# Altium schematic sheet Voltage Signal Measuring.SchDoc("Voltage Signal Measuring")
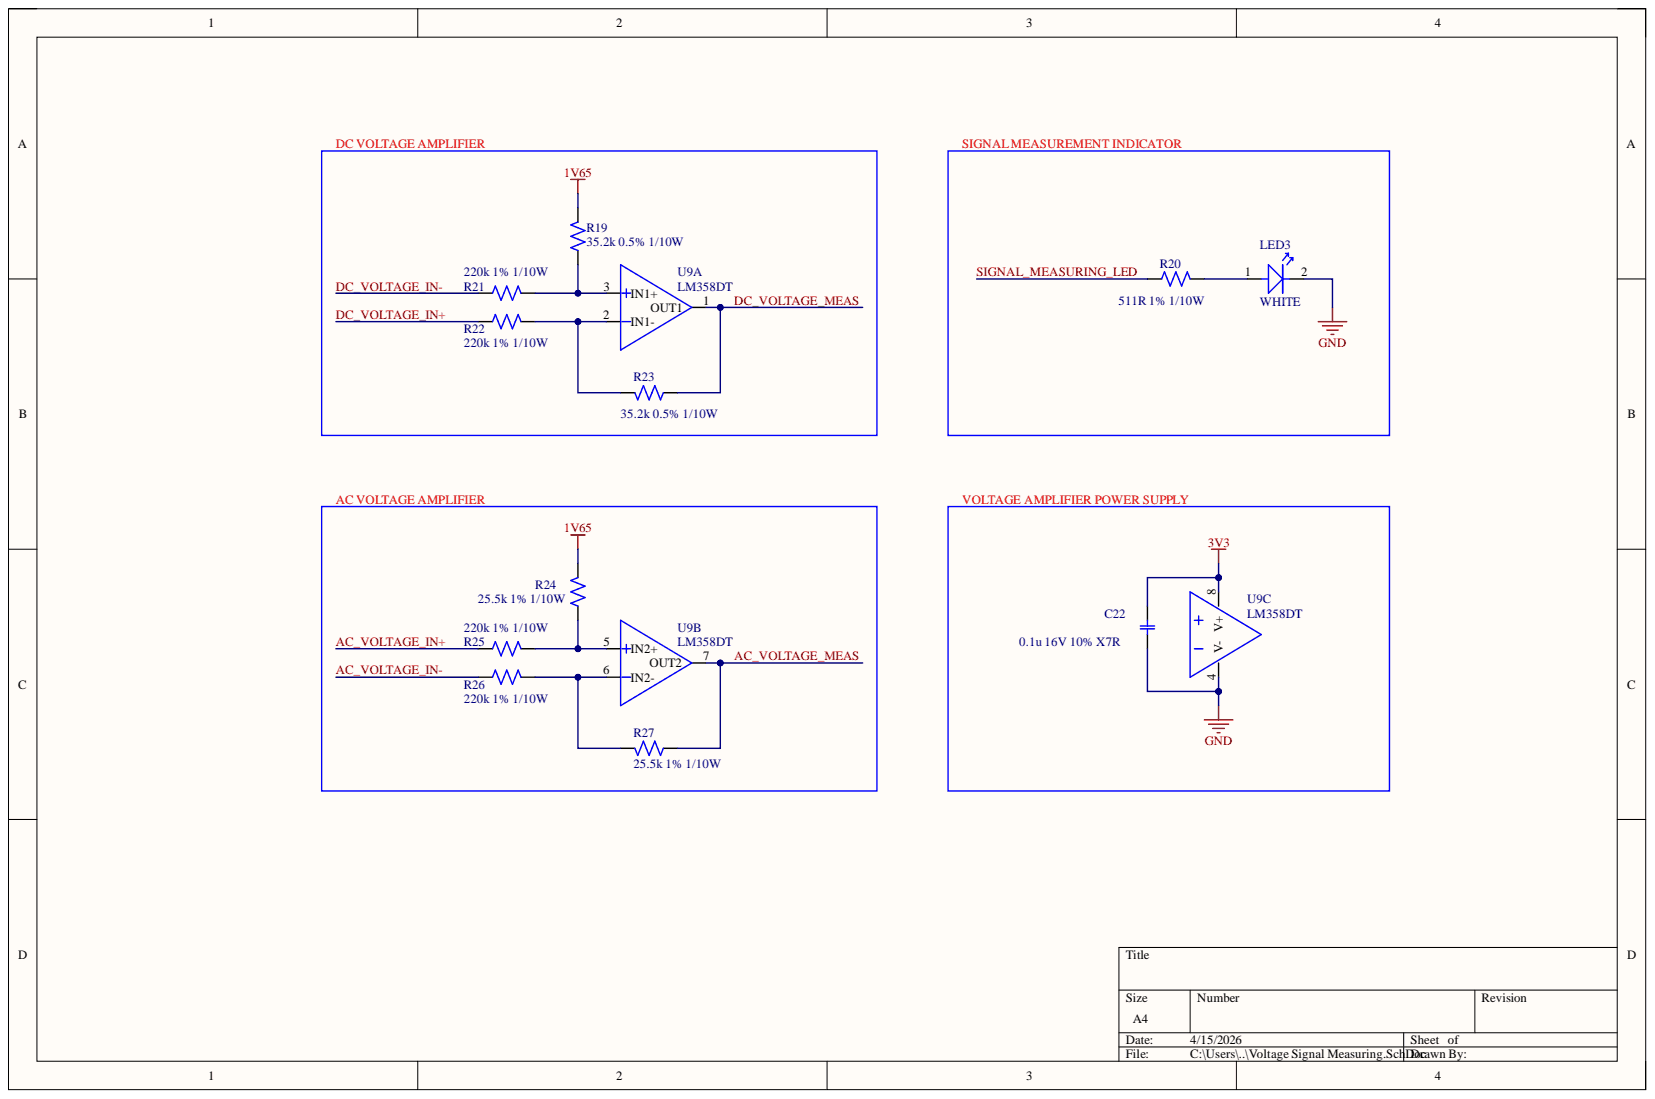
[diagram p0: Voltage Signal Measuring.SchDoc]

## Parts
C22  0.1u 16V 10% X7R  Dielectric: X7R  [0603-C]
LED3  WHITE  [0603 - LED]
R19  35.2k 0.5% 1/10W  [0603-R]
R20  511R 1% 1/10W  Tolerance: 1%  [0603-R]
R21  220k 1% 1/10W  Tolerance: 1%  [0603-R]
R22  220k 1% 1/10W  Tolerance: 1%  [0603-R]
R23  35.2k 0.5% 1/10W  Tolerance: 0.5%  [0603-R]
R24  25.5k 1% 1/10W  [0603-R]
R25  220k 1% 1/10W  Tolerance: 1%  [0603-R]
R26  220k 1% 1/10W  Tolerance: 1%  [0603-R]
R27  25.5k 1% 1/10W  Tolerance: 1%  [0603-R]
U9  LM358DT  [LM358DT]  (parts A,B,C)

## Nets
1V65: R19.1 R24.1
3V3: C22.1 U9.8(V+)
GND: C22.2 LED3.2 U9.4(V-)
AC_VOLTAGE_IN+: R25.2
AC_VOLTAGE_IN-: R26.2
AC_VOLTAGE_MEAS: R27.2 U9.7(OUT2)
DC_VOLTAGE_IN+: R22.2
DC_VOLTAGE_IN-: R21.2
DC_VOLTAGE_MEAS: R23.2 U9.1(OUT1)
SIGNAL_MEASURING_LED: R20.2
NetLED3_1: LED3.1 R20.1
NetR19_2: R19.2 R21.1 U9.3(IN1+)
NetR22_1: R22.1 R23.1 U9.2(IN1-)
NetR24_2: R24.2 R25.1 U9.5(IN2+)
NetR26_1: R26.1 R27.1 U9.6(IN2-)

## Library data of the parts
C22 = CC0603KRX7R7BB104: 0603 0.1 uF 16 V ±10 % Tolerance X7R SMT Multilayer Ceramic Capacitor; Manufacturer: Yageo; Insulation Resistance: 100GR; Value: 100nF; Case Code (Imperial): 0603; Case Code (Metric): 1608; Case/Package: 0603; Category: Ceramic Capacitors; Component Kind: Standard; ComponentLink1Description: https://datasheet.ciiva.com/11786/0900766b80d84f1d-11786077.pdf?src-supplier=Rs; ComponentLink1URL: https://datasheet.ciiva.com/11786/0900766b80d84f1d-11786077.pdf?src-supplier=Rs; ComponentLink2Description: https://datasheet.ciiva.com/144/mlccorderingcode-144182.pdf?src-supplier=Digikey; ComponentLink2URL: https://datasheet.ciiva.com/144/mlccorderingcode-144182.pdf?src-supplier=Digikey; ComponentLink3Description: https://datasheet.ciiva.com/144/mlccorderingcode-144183.pdf?src-supplier=Digikey; ComponentLink3URL: https://datasheet.ciiva.com/144/mlccorderingcode-144183.pdf?src-supplier=Digikey; ComponentLink4Description: https://datasheet.ciiva.com/144/mlccorderingcode-144184.pdf?src-supplier=Digikey; ComponentLink4URL: https://datasheet.ciiva.com/144/mlccorderingcode-144184.pdf?src-supplier=Digikey; ComponentLink5Description: https://datasheet.ciiva.com/7978/1489800-7978141.pdf?src-supplier=Element14; ComponentLink5URL: https://datasheet.ciiva.com/7978/1489800-7978141.pdf?src-supplier=Element14; ComponentLink6Description: https://datasheet.ciiva.com/11464/upy-gphc-x7r-6-3v-to-50v-8-11464735.pdf?src-supplier=Avnet; ComponentLink6URL: https://datasheet.ciiva.com/11464/upy-gphc-x7r-6-3v-to-50v-8-11464735.pdf?src-supplier=Avnet; ComponentLink7Description: https://datasheet.ciiva.com/28450/1489800-28450552.pdf?src-supplier=Element14; ComponentLink7URL: https://datasheet.ciiva.com/28450/1489800-28450552.pdf?src-supplier=Element14; ComponentLink8Description: https://datasheet.ciiva.com/46430/1734573-46430156.pdf?src-supplier=Element14; ComponentLink8URL: https://datasheet.ciiva.com/46430/1734573-46430156.pdf?src-supplier=Element14; ComponentLink9Description: https://datasheet.ciiva.com/8033/1734573-8033797.pdf?src-supplier=Element14; ComponentLink9URL: https://datasheet.ciiva.com/8033/1734573-8033797.pdf?src-supplier=Element14; ComponentLink10Description: https://datasheet.ciiva.com/8033/70765-8033799.pdf?src-supplier=Element14; ComponentLink10URL: https://datasheet.ciiva.com/8033/70765-8033799.pdf?src-supplier=Element14; ComponentLink11Description: https://datasheet.ciiva.com/15165/getdatasheetpartid-63554-15165387.pdf?src-supplier=Verical; ComponentLink11URL: https://datasheet.ciiva.com/15165/getdatasheetpartid-63554-15165387.pdf?src-supplier=Verical; ComponentLink12Description: https://datasheet.ciiva.com/13395/upy-gphc-x7r-6-3v-to-50v-8-13395674.pdf?src-supplier=Mouser; ComponentLink12URL: https://datasheet.ciiva.com/13395/upy-gphc-x7r-6-3v-to-50v-8-13395674.pdf?src-supplier=Mouser; Depth: 0.8mm; Halogen Free: Halogen Free; Height: 0.8mm; Lead Free: Lead Free; Length: 1.6mm; Manufacturer Part Number: CC0603KRX7R7BB104; Material: Ceramic; Max Operating Temperature: 125°C; Min Operating Temperature: -55°C; Mounting Technology: Surface Mount; Package Quantity: 1; Packaging: Tape and Reel; Pins: 2; Pricing: 1=0.08, 10=0.014, 50=0.012, 100=0.0096, 500=0.0072, 1000=0.0064 (USD); Radiation Hardening: No; Reach SVHC Compliant: No; RoHS: Yes; RoHS Compliant: Yes; Series: CC; Stock: 7111175; Supplier 1: Digikey; Supplier Part Number 1: 311-1088-1-ND; Termination: SMD/SMT; Thickness: 0.035inch; Tolerance: 10%; Voltage: 16V; Voltage Rating: 16V; Voltage Rating (DC): 16V; Width: 0.031inch; Library Name: tpmania.SchLib
LED3 = LTST-T180UWET: SMD - PLCC LED , Top View Single Color PLCC; Manufacturer: Lite-On; Forward Current: 30mA; Forward Voltage: 3.25V; Luminous Intensity: 1.5cd; Number of Circuits: 1; Shape: Rectangular; Viewing Angle: 120°; Category: LEDs; Color: White; Component Kind: Standard; ComponentLink1Description: https://datasheet.octopart.com:443/LTST-T180UWET-Lite-On-datasheet-180605454.pdf?src-supplier=IHS; ComponentLink1URL: https://datasheet.octopart.com:443/LTST-T180UWET-Lite-On-datasheet-180605454.pdf?src-supplier=IHS; Harmonized Tariff Schedule (HTS) Code: 8541.40.20.00; Height: 550µm; Introduction Date: 2020-06-02; Library Name: ENGG3800 schematic library.SchLib; Lifecycle Status: Production; Manufacturer Part Number: LTST-T180UWET; Max Operating Temperature: 100°C; Min Operating Temperature: -40°C; Mounting Technology: Surface Mount; Number of Terminals: 2; Pricing: 5000=0.04655, 10000=0.0455, 20000=0.04445, 40000=0.0434, 80000=0.04235 (USD); REACH SVHC: Yes; RoHS: Compliant; Stock: 10000; Supplier 1: Digikey; Supplier Part Number 1: 160-LTST-T180UWETCT-ND; Terminal Pitch: 850µm
R19 R23 = RT0603DRE0735K2L: High Precision-High Stability Chip Resistor Thin Film 0603 35.2kOhm 0.5% Paper T/R; Manufacturer: Yageo Group; Power Dissipation: 100mW; Temperature Coefficient: 50ppm/°C; Value: 35.2kΩ; Working Voltage: 75V; Case/Package: 0603; Category: Chip SMD Resistors; China RoHS: Non-Compliant; Component Kind: Standard; ComponentLink1Description: https://datasheet.octopart.com:443/RT0603DRE0735K2L-Yageo-datasheet-137700930.pdf?src-supplier=IHS; ComponentLink1URL: https://datasheet.octopart.com:443/RT0603DRE0735K2L-Yageo-datasheet-137700930.pdf?src-supplier=IHS; ComponentLink2Description: https://datasheet.octopart.com/RT0603DRE0735K2L-Yageo-datasheet-86813807.pdf; ComponentLink2URL: https://datasheet.octopart.com/RT0603DRE0735K2L-Yageo-datasheet-86813807.pdf; ComponentLink3Description: http://sigma.octopart.com:80/conflict_mineral_statement/Yageo-company-3.pdf?src-supplier=Compliance+Statements; ComponentLink3URL: http://sigma.octopart.com:80/conflict_mineral_statement/Yageo-company-3.pdf?src-supplier=Compliance+Statements; ComponentLink4Description: http://sigma.octopart.com:80/rohs_statement/Yageo-company-2.pdf?src-supplier=Compliance+Statements; ComponentLink4URL: http://sigma.octopart.com:80/rohs_statement/Yageo-company-2.pdf?src-supplier=Compliance+Statements; Height: 450µm; Length: 1.6mm; Library Name: ENGG3800 schematic library.SchLib; Lifecycle Status: Production; Manufacturer Part Number: RT0603DRE0735K2L; Max Operating Temperature: 125°C; Min Operating Temperature: -55°C; Mounting Technology: Surface Mount; Number of Terminals: 2; Packaging: Tape & Reel; Pricing: 1=0.1, 10=0.016, 25=0.016, 50=0.0154, 100=0.0148, 250=0.01428, 500=0.01348 (USD); REACH SVHC: Yes; RoHS: Compliant; Stock: 25571; Supplier 1: DigiKey; Supplier Part Number 1: 13-RT0603DRE0735K2LCT-ND; Width: 800µm
  R19: Tolerance: 0.5%
R20 = RC0603FR-07511RL: 100mW Thick Film Resistors 75V ±100ppm/℃ ±1% 511Ω 0603 Chip Resistor - Surface Mount ROHS; Manufacturer: Yageo Group; Temperature Coefficient: 100ppm/°C; Voltage Rating (DC): 50V; Case Code (Imperial): 0603; Case Code (Metric): 1608; Case/Package: 0603; Category: Chip SMD Resistors; China RoHS: Non-Compliant; Component Kind: Standard; ComponentLink1Description: https://datasheet.octopart.com:443/RC0603FR-07511RL-Yageo-datasheet-175887523.pdf?src-supplier=Newark; ComponentLink1URL: https://datasheet.octopart.com:443/RC0603FR-07511RL-Yageo-datasheet-175887523.pdf?src-supplier=Newark; ComponentLink2Description: https://datasheet.octopart.com:443/RC0603FR-07511RL-Yageo-datasheet-103092701.pdf?src-supplier=Upverter; ComponentLink2URL: https://datasheet.octopart.com:443/RC0603FR-07511RL-Yageo-datasheet-103092701.pdf?src-supplier=Upverter; ComponentLink3Description: https://datasheet.octopart.com:443/RC0603FR-07511RL-Yageo-Group-datasheet-176638170.pdf?src-supplier=Future+Electronics; ComponentLink3URL: https://datasheet.octopart.com:443/RC0603FR-07511RL-Yageo-Group-datasheet-176638170.pdf?src-supplier=Future+Electronics; ComponentLink4Description: https://datasheet.octopart.com:443/RC0603FR-07511RL-Yageo-datasheet-164759695.pdf?src-supplier=Future+Electronics; ComponentLink4URL: https://datasheet.octopart.com:443/RC0603FR-07511RL-Yageo-datasheet-164759695.pdf?src-supplier=Future+Electronics; ComponentLink5Description: https://datasheet.octopart.com:443/RC0603FR-07511RL-Yageo-datasheet-137700087.pdf?src-supplier=Future+Electronics; ComponentLink5URL: https://datasheet.octopart.com:443/RC0603FR-07511RL-Yageo-datasheet-137700087.pdf?src-supplier=Future+Electronics; ComponentLink6Description: https://datasheet.octopart.com:443/RC0603FR-07511RL-Yageo-datasheet-15599030.pdf?src-supplier=Future+Electronics; ComponentLink6URL: https://datasheet.octopart.com:443/RC0603FR-07511RL-Yageo-datasheet-15599030.pdf?src-supplier=Future+Electronics; ComponentLink7Description: https://datasheet.octopart.com:443/RC0603FR-07511RL-Yageo-datasheet-12596169.pdf?src-supplier=Future+Electronics; ComponentLink7URL: https://datasheet.octopart.com:443/RC0603FR-07511RL-Yageo-datasheet-12596169.pdf?src-supplier=Future+Electronics; ComponentLink8Description: https://datasheet.octopart.com:443/RC0603FR-07511RL-Yageo-datasheet-8328172.pdf?src-supplier=Future+Electronics; ComponentLink8URL: https://datasheet.octopart.com:443/RC0603FR-07511RL-Yageo-datasheet-8328172.pdf?src-supplier=Future+Electronics; ComponentLink9Description: https://datasheet.octopart.com:443/RC0603FR-07511RL-Yageo-datasheet-503470.pdf?src-supplier=Future+Electronics; ComponentLink9URL: https://datasheet.octopart.com:443/RC0603FR-07511RL-Yageo-datasheet-503470.pdf?src-supplier=Future+Electronics; ComponentLink10Description: https://datasheet.octopart.com:443/RC0603FR-07511RL-Yageo-datasheet-148775077.pdf?src-supplier=TME; ComponentLink10URL: https://datasheet.octopart.com:443/RC0603FR-07511RL-Yageo-datasheet-148775077.pdf?src-supplier=TME; ComponentLink11Description: https://datasheet.octopart.com/RC0603FR-07511RL-Yageo-datasheet-73766026.pdf; ComponentLink11URL: https://datasheet.octopart.com/RC0603FR-07511RL-Yageo-datasheet-73766026.pdf; ComponentLink12Description: http://sigma.octopart.com:80/conflict_mineral_statement/Yageo-company-3.pdf?src-supplier=Compliance+Statements; ComponentLink12URL: http://sigma.octopart.com:80/conflict_mineral_statement/Yageo-company-3.pdf?src-supplier=Compliance+Statements; ComponentLink13Description: http://sigma.octopart.com:80/rohs_statement/Yageo-company-2.pdf?src-supplier=Compliance+Statements; ComponentLink13URL: http://sigma.octopart.com:80/rohs_statement/Yageo-company-2.pdf?src-supplier=Compliance+Statements; Composition: Thick Film; Depth: 800µm; Height: 450µm; Lead Free: Lead Free; Length: 1.6mm; Library Name: ENGG3800 schematic library.SchLib; Lifecycle Status: Production; Manufacturer Part Number: RC0603FR-07511RL; Max Operating Temperature: 155°C; Military Standard: Not; Min Operating Temperature: -55°C; Mounting Technology: Surface Mount; Number of Terminals: 2; Packaging: Tape & Reel; Power Dissipation: 100mW; Power Rating: 100mW; Pricing: 1=0.103, 25=0.009, 50=0.008, 100=0.007, 500=0.006 (USD); REACH SVHC: Yes; RoHS: Compliant; Schedule B: 8533210045, 8533210045|8533210045|8533210045|8533210045|8533210045; Stock: 5464; Supplier 1: Digikey; Supplier Part Number 1: 311-511HRCT-ND; Termination: SMD/SMT; Value: 511Ω; Voltage Rating: 75V; Weight: 2.012816mg; Width: 800µm; Working Voltage: 75V
R21 R22 R25 R26 = RMCF0603FT220K: Res Thick Film 0603 220K Ohm 1% 0.1W(1/10W) ±100ppm/C Pad SMD Automotive T/R; Manufacturer: Stackpole Electronics; Max Power Dissipation: 100mW; Temperature Coefficient: 100ppm/°C; Value: 220kΩ; Voltage Rating: 75V; Case Code (Imperial): 0603; Case Code (Metric): 1608; Case/Package: 0603; Category: Chip SMD Resistors; China RoHS: Non-Compliant; Component Kind: Standard; ComponentLink1Description: https://datasheet.octopart.com:443/RMCF0603FT220K-Stackpole-Electronics-datasheet-182148065.pdf?src-supplier=IHS; ComponentLink1URL: https://datasheet.octopart.com:443/RMCF0603FT220K-Stackpole-Electronics-datasheet-182148065.pdf?src-supplier=IHS; ComponentLink2Description: https://datasheet.octopart.com:443/RMCF0603FT220K-Stackpole-Electronics-datasheet-28504820.pdf?src-supplier=Upverter; ComponentLink2URL: https://datasheet.octopart.com:443/RMCF0603FT220K-Stackpole-Electronics-datasheet-28504820.pdf?src-supplier=Upverter; ComponentLink3Description: https://datasheet.octopart.com:443/RMCF0603FT220K-Stackpole-Electronics-datasheet-141193804.pdf?src-supplier=Stackpole+Electronics; ComponentLink3URL: https://datasheet.octopart.com:443/RMCF0603FT220K-Stackpole-Electronics-datasheet-141193804.pdf?src-supplier=Stackpole+Electronics; ComponentLink4Description: https://datasheet.octopart.com:443/RMCF0603FT220K-Stackpole-Electronics-datasheet-62091608.pdf?src-supplier=Stackpole+Electronics; ComponentLink4URL: https://datasheet.octopart.com:443/RMCF0603FT220K-Stackpole-Electronics-datasheet-62091608.pdf?src-supplier=Stackpole+Electronics; ComponentLink5Description: https://datasheet.octopart.com:443/RMCF0603FT220K-Stackpole-Electronics-datasheet-12534581.pdf?src-supplier=Stackpole+Electronics; ComponentLink5URL: https://datasheet.octopart.com:443/RMCF0603FT220K-Stackpole-Electronics-datasheet-12534581.pdf?src-supplier=Stackpole+Electronics; ComponentLink6Description: https://datasheet.octopart.com:443/RMCF0603FT220K-Stackpole-Electronics-datasheet-10788263.pdf?src-supplier=Stackpole+Electronics; ComponentLink6URL: https://datasheet.octopart.com:443/RMCF0603FT220K-Stackpole-Electronics-datasheet-10788263.pdf?src-supplier=Stackpole+Electronics; ComponentLink7Description: http://sigma.octopart.com:80/15940719/materials_sheet/Stackpole-Electronics-RMCF0603FT220K.pdf?src-supplier=Compliance+Statements; ComponentLink7URL: http://sigma.octopart.com:80/15940719/materials_sheet/Stackpole-Electronics-RMCF0603FT220K.pdf?src-supplier=Compliance+Statements; ComponentLink8Description: http://sigma.octopart.com:80/141158211/reach_statement/Stackpole-Electronics-RMCF0603FT220K.pdf?src-supplier=Compliance+Statements; ComponentLink8URL: http://sigma.octopart.com:80/141158211/reach_statement/Stackpole-Electronics-RMCF0603FT220K.pdf?src-supplier=Compliance+Statements; ComponentLink9Description: http://sigma.octopart.com:80/141158212/rohs_statement/Stackpole-Electronics-RMCF0603FT220K.pdf?src-supplier=Compliance+Statements; ComponentLink9URL: http://sigma.octopart.com:80/141158212/rohs_statement/Stackpole-Electronics-RMCF0603FT220K.pdf?src-supplier=Compliance+Statements; Composition: Thick Film; Contact Plating: Tin; Depth: 800µm; Features: Automotive AEC-Q200; Height: 450µm; Lead Free: Lead Free; Length: 1.55mm; Library Name: ENGG3800 schematic library.SchLib; Lifecycle Status: Production; Manufacturer Part Number: RMCF0603FT220K; Max Operating Temperature: 155°C; Military Standard: Not; Min Operating Temperature: -55°C; Mounting Technology: Surface Mount; Number of Terminals: 2; Number of Terminations: 2; Packaging: Tape & Reel (TR); Power Dissipation: 100mW; Power Rating: 100mW; Pricing: 5000=0.0019, 10000=0.00188, 20000=0.00185, 40000=0.00182, 80000=0.00179 (USD); Radiation Hardening: No; REACH SVHC: Yes; RoHS: Compliant; Schedule B: 8533210045, 8533210045|8533210045, 8533210045|8533210045|8533210045, 8533210045|8533210045|8533210045|8533210045; Stock: 385000; Supplier 1: Digikey; Supplier Part Number 1: RMCF0603FT220KCT-ND; Width: 800µm; Working Voltage: 75V
R24 R27 = RC0603FR-0725K5L: Res Thick Film 0603 25.5K Ohm 1% 0.1W(1/10W) ±100ppm/C Pad SMD T/R; Manufacturer: Yageo Group; Max Power Dissipation: 100mW; Temperature Coefficient: 100ppm/°C; Value: 25.5kΩ; Voltage Rating: 75V; Voltage Rating (DC): 50V; Case Code (Imperial): 0603; Case/Package: 0603; Category: Chip SMD Resistors; China RoHS: Non-Compliant; Component Kind: Standard; ComponentLink1Description: https://datasheet.octopart.com:443/RC0603FR-0725K5L-Yageo-datasheet-175887523.pdf?src-supplier=Newark; ComponentLink1URL: https://datasheet.octopart.com:443/RC0603FR-0725K5L-Yageo-datasheet-175887523.pdf?src-supplier=Newark; ComponentLink2Description: https://datasheet.octopart.com:443/RC0603FR-0725K5L-Yageo-datasheet-103092701.pdf?src-supplier=Upverter; ComponentLink2URL: https://datasheet.octopart.com:443/RC0603FR-0725K5L-Yageo-datasheet-103092701.pdf?src-supplier=Upverter; ComponentLink3Description: https://datasheet.octopart.com:443/RC0603FR-0725K5L-Yageo-Group-datasheet-190305687.pdf?src-supplier=Future+Electronics; ComponentLink3URL: https://datasheet.octopart.com:443/RC0603FR-0725K5L-Yageo-Group-datasheet-190305687.pdf?src-supplier=Future+Electronics; ComponentLink4Description: https://datasheet.octopart.com:443/RC0603FR-0725K5L-Yageo-datasheet-164759695.pdf?src-supplier=Future+Electronics; ComponentLink4URL: https://datasheet.octopart.com:443/RC0603FR-0725K5L-Yageo-datasheet-164759695.pdf?src-supplier=Future+Electronics; ComponentLink5Description: https://datasheet.octopart.com:443/RC0603FR-0725K5L-Yageo-datasheet-137700087.pdf?src-supplier=Future+Electronics; ComponentLink5URL: https://datasheet.octopart.com:443/RC0603FR-0725K5L-Yageo-datasheet-137700087.pdf?src-supplier=Future+Electronics; ComponentLink6Description: https://datasheet.octopart.com:443/RC0603FR-0725K5L-Yageo-datasheet-17842380.pdf?src-supplier=Future+Electronics; ComponentLink6URL: https://datasheet.octopart.com:443/RC0603FR-0725K5L-Yageo-datasheet-17842380.pdf?src-supplier=Future+Electronics; ComponentLink7Description: https://datasheet.octopart.com:443/RC0603FR-0725K5L-Yageo-datasheet-15599030.pdf?src-supplier=Future+Electronics; ComponentLink7URL: https://datasheet.octopart.com:443/RC0603FR-0725K5L-Yageo-datasheet-15599030.pdf?src-supplier=Future+Electronics; ComponentLink8Description: https://datasheet.octopart.com:443/RC0603FR-0725K5L-Yageo-datasheet-12596169.pdf?src-supplier=Future+Electronics; ComponentLink8URL: https://datasheet.octopart.com:443/RC0603FR-0725K5L-Yageo-datasheet-12596169.pdf?src-supplier=Future+Electronics; ComponentLink9Description: https://datasheet.octopart.com:443/RC0603FR-0725K5L-Yageo-datasheet-8328172.pdf?src-supplier=Future+Electronics; ComponentLink9URL: https://datasheet.octopart.com:443/RC0603FR-0725K5L-Yageo-datasheet-8328172.pdf?src-supplier=Future+Electronics; ComponentLink10Description: https://datasheet.octopart.com:443/RC0603FR-0725K5L-Yageo-Group-datasheet-189192902.pdf?src-supplier=TME; ComponentLink10URL: https://datasheet.octopart.com:443/RC0603FR-0725K5L-Yageo-Group-datasheet-189192902.pdf?src-supplier=TME; ComponentLink11Description: https://datasheet.octopart.com:443/RC0603FR-0725K5L-Yageo-Group-datasheet-176638170.pdf?src-supplier=TME; ComponentLink11URL: https://datasheet.octopart.com:443/RC0603FR-0725K5L-Yageo-Group-datasheet-176638170.pdf?src-supplier=TME; ComponentLink12Description: https://datasheet.octopart.com/RC0603FR-0725K5L-Yageo-datasheet-73766026.pdf; ComponentLink12URL: https://datasheet.octopart.com/RC0603FR-0725K5L-Yageo-datasheet-73766026.pdf; ComponentLink13Description: https://datasheet.octopart.com:443/RC0603FR-0725K5L-Yageo-datasheet-118562.pdf?src-supplier=DigiKey; ComponentLink13URL: https://datasheet.octopart.com:443/RC0603FR-0725K5L-Yageo-datasheet-118562.pdf?src-supplier=DigiKey; ComponentLink14Description: http://sigma.octopart.com:80/rohs_statement/Yageo-company-2.pdf?src-supplier=Compliance+Statements; ComponentLink14URL: http://sigma.octopart.com:80/rohs_statement/Yageo-company-2.pdf?src-supplier=Compliance+Statements; ComponentLink15Description: http://sigma.octopart.com:80/conflict_mineral_statement/Yageo-company-3.pdf?src-supplier=Compliance+Statements; ComponentLink15URL: http://sigma.octopart.com:80/conflict_mineral_statement/Yageo-company-3.pdf?src-supplier=Compliance+Statements; Composition: Thick Film; Depth: 800µm; Features: Moisture Resistant; Height: 450µm; Lead Free: Lead Free; Length: 1.6mm; Library Name: ENGG3800 schematic library.SchLib; Lifecycle Status: Production; Manufacturer Part Number: RC0603FR-0725K5L; Max Operating Temperature: 155°C; Military Standard: Not; Min Operating Temperature: -55°C; Mounting Technology: Surface Mount; Number of Terminals: 2; Number of Terminations: 2; Power Dissipation: 100mW; Power Rating: 100mW; Pricing: 1=0.103, 25=0.007, 100=0.006 (USD); REACH SVHC: Yes; RoHS: Compliant; Schedule B: 8533210045, 8533210045|8533210045|8533210045|8533210045|8533210045|8533210045|8533210045|8533210045|8533210045|8533210045|8533210045|8533210045|8533210045|8533210045|8533210045|8533210045|8533210045|8533210045|8533210045|8533210045|8533210045|8533210045|8533210045|85; Stock: 20004; Supplier 1: Digikey; Supplier Part Number 1: 311-25.5KHRCT-ND; Termination: SMD/SMT; Width: 800µm; Working Voltage: 75V
  R24: Tolerance: 1%
U9 = LM358DT: Integrated Circuit Operational Amplifier; CH: 2; 1.1MHz; 3-32V; 0+70 deg.C; SMD; SO8; Manufacturer: STMicroelectronics; Common Mode Rejection Ratio: 60dB; Dual Supply Voltage: 9V; Input Bias Current: 150nA; Input Offset Voltage (Vos): 9mV; Max Dual Supply Voltage: 15V; Max Junction Temperature (Tj): 150°C; Max Output current: 60mA; Max Supply Current: 2mA; Max Supply Voltage: 28.5V; Min Dual Supply Voltage: 1.5V; Min Input Voltage: 0V; Min Supply Voltage: 3V; Nominal Supply Current: 350µA; Number of Amplifiers: 2; Operating Supply Current: 700µA; Operating Supply Voltage: 5V; Slew Rate: 0.3V/µs; Voltage Gain: 100dB; Bandwidth: 1.1MHz; Case/Package: SOP; Category: Amplifiers - Op Amps, Buffer, Instrumentation; China RoHS: Compliant; Component Kind: Standard; ComponentLink1Description: https://datasheet.octopart.com:443/LM358DT-STMicroelectronics-datasheet-116606904.pdf?src-supplier=ODG+(Origin+Data+Global); ComponentLink1URL: https://datasheet.octopart.com:443/LM358DT-STMicroelectronics-datasheet-116606904.pdf?src-supplier=ODG+(Origin+Data+Global); ComponentLink2Description: https://datasheet.octopart.com:443/LM358DT-STMicroelectronics-datasheet-61210252.pdf?src-supplier=element14+APAC; ComponentLink2URL: https://datasheet.octopart.com:443/LM358DT-STMicroelectronics-datasheet-61210252.pdf?src-supplier=element14+APAC; ComponentLink3Description: https://datasheet.octopart.com:443/LM358DT-STMicroelectronics-datasheet-11005313.pdf?src-supplier=STMicroelectronics; ComponentLink3URL: https://datasheet.octopart.com:443/LM358DT-STMicroelectronics-datasheet-11005313.pdf?src-supplier=STMicroelectronics; ComponentLink4Description: https://datasheet.octopart.com:443/LM358DT-STMicroelectronics-datasheet-10410245.pdf?src-supplier=Future+Electronics; ComponentLink4URL: https://datasheet.octopart.com:443/LM358DT-STMicroelectronics-datasheet-10410245.pdf?src-supplier=Future+Electronics; ComponentLink5Description: https://datasheet.octopart.com:443/LM358DT-STMicroelectronics-datasheet-529748.pdf?src-supplier=element14; ComponentLink5URL: https://datasheet.octopart.com:443/LM358DT-STMicroelectronics-datasheet-529748.pdf?src-supplier=element14; ComponentLink6Description: https://datasheet.octopart.com:443/LM358DT-STMicroelectronics-datasheet-109535.pdf?src-supplier=element14; ComponentLink6URL: https://datasheet.octopart.com:443/LM358DT-STMicroelectronics-datasheet-109535.pdf?src-supplier=element14; ComponentLink7Description: https://datasheet.octopart.com:443/LM358DT-STMicroelectronics-datasheet-81668593.pdf?src-supplier=SHENGYU+ELECTRONICS; ComponentLink7URL: https://datasheet.octopart.com:443/LM358DT-STMicroelectronics-datasheet-81668593.pdf?src-supplier=SHENGYU+ELECTRONICS; ComponentLink8Description: https://datasheet.octopart.com:443/LM358DT-STMicroelectronics-datasheet-36993016.pdf?src-supplier=TME; ComponentLink8URL: https://datasheet.octopart.com:443/LM358DT-STMicroelectronics-datasheet-36993016.pdf?src-supplier=TME; ComponentLink9Description: https://datasheet.octopart.com:443/LM358DT-STMicroelectronics-datasheet-57.pdf?src-supplier=Newark; ComponentLink9URL: https://datasheet.octopart.com:443/LM358DT-STMicroelectronics-datasheet-57.pdf?src-supplier=Newark; ComponentLink10Description: https://datasheet.octopart.com:443/LM358DT-STMicroelectronics-datasheet-15994790.pdf?src-supplier=Newark; ComponentLink10URL: https://datasheet.octopart.com:443/LM358DT-STMicroelectronics-datasheet-15994790.pdf?src-supplier=Newark; ComponentLink11Description: https://datasheet.octopart.com:443/LM358DT-STMicroelectronics-datasheet-14137276.pdf?src-supplier=iiiC; ComponentLink11URL: https://datasheet.octopart.com:443/LM358DT-STMicroelectronics-datasheet-14137276.pdf?src-supplier=iiiC; ComponentLink12Description: https://datasheet.octopart.com:443/LM358DT-STMicroelectronics-datasheet-9802834.pdf?src-supplier=RS+(Formerly+Allied+Electronics); ComponentLink12URL: https://datasheet.octopart.com:443/LM358DT-STMicroelectronics-datasheet-9802834.pdf?src-supplier=RS+(Formerly+Allied+Electronics); ComponentLink13Description: https://datasheet.octopart.com:443/LM358DT-STMicroelectronics-datasheet-5352292.pdf?src-supplier=Farnell; ComponentLink13URL: https://datasheet.octopart.com:443/LM358DT-STMicroelectronics-datasheet-5352292.pdf?src-supplier=Farnell; Contact Plating: Tin; Country of Origin: Mainland China; Export Control Classification Number (ECCN) Code: EAR99; Gain Bandwidth Product: 1.1MHz; Harmonized Tariff Schedule (HTS) Code: 8542.33.00.01; Height: 1.75mm; Height - Seated (Max): 1.75mm; Introduction Date: 1980-01-04; Lead Free: Lead Free; Length: 4.9mm; Library Name: ENGG3800 schematic library.SchLib; Lifecycle Status: Production; Manufacturer Part Number: LM358DT; Max Operating Temperature: 70°C; Min Operating Temperature: 0°C; Mounting Technology: Surface Mount; Number of Channels: 2; Number of Circuits: 2; Number of Elements: 2; Number of Terminals: 2; Output Current: 60mA; Output Current per Channel: 60mA; Packaging: Tape and Reel; Pins: 8; Pricing: 2500=0.0538, 5000=0.05346, 10000=0.05247, 20000=0.05152, 40000=0.0506 (USD); Radiation Hardening: No; REACH SVHC: Yes; RoHS: Compliant; Schedule B: 8542330000, 8542330000|8542330000|8542330000|8542330000|8542330000|8542330000|8542330000|8542330000|8542330000|8542330000|8542330000|8542330000|8542330000|8542330000|8542330000|8542330000|8542330000|8542330000|8542330000|8542330000|8542330000|8542330000|8542330000|85; Stock: 97500; Supplier 1: Digikey; Supplier Part Number 1: 497-1591-1-ND; Temperature Grade: Commercial; Terminal Pitch: 1.27mm; Unity Gain Bandwidth Product: 1.1MHz; Weight: 4.535924g; Width: 3.9mm
